# Altium schematic sheet Top Level.SchDoc("Top Level")
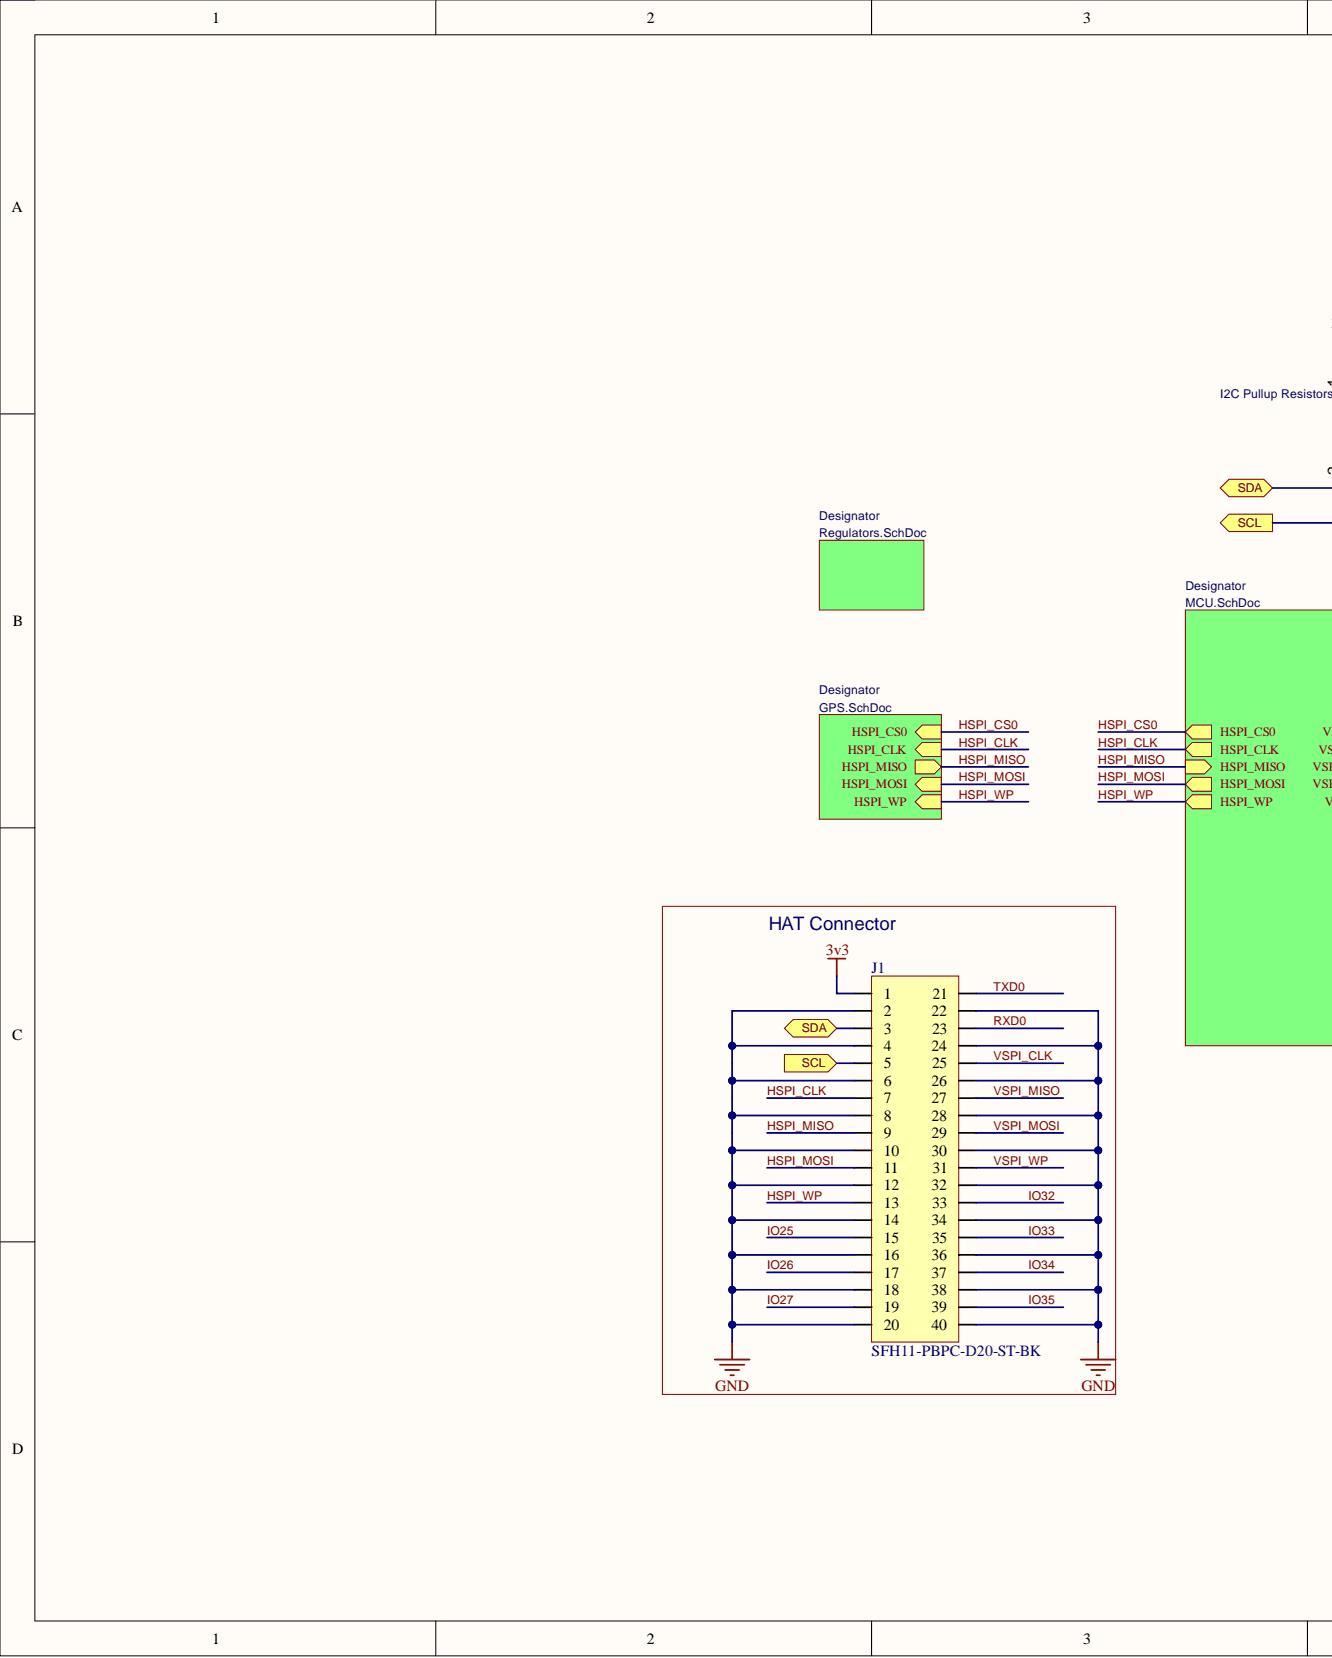
[diagram p0: Top Level.SchDoc, left]
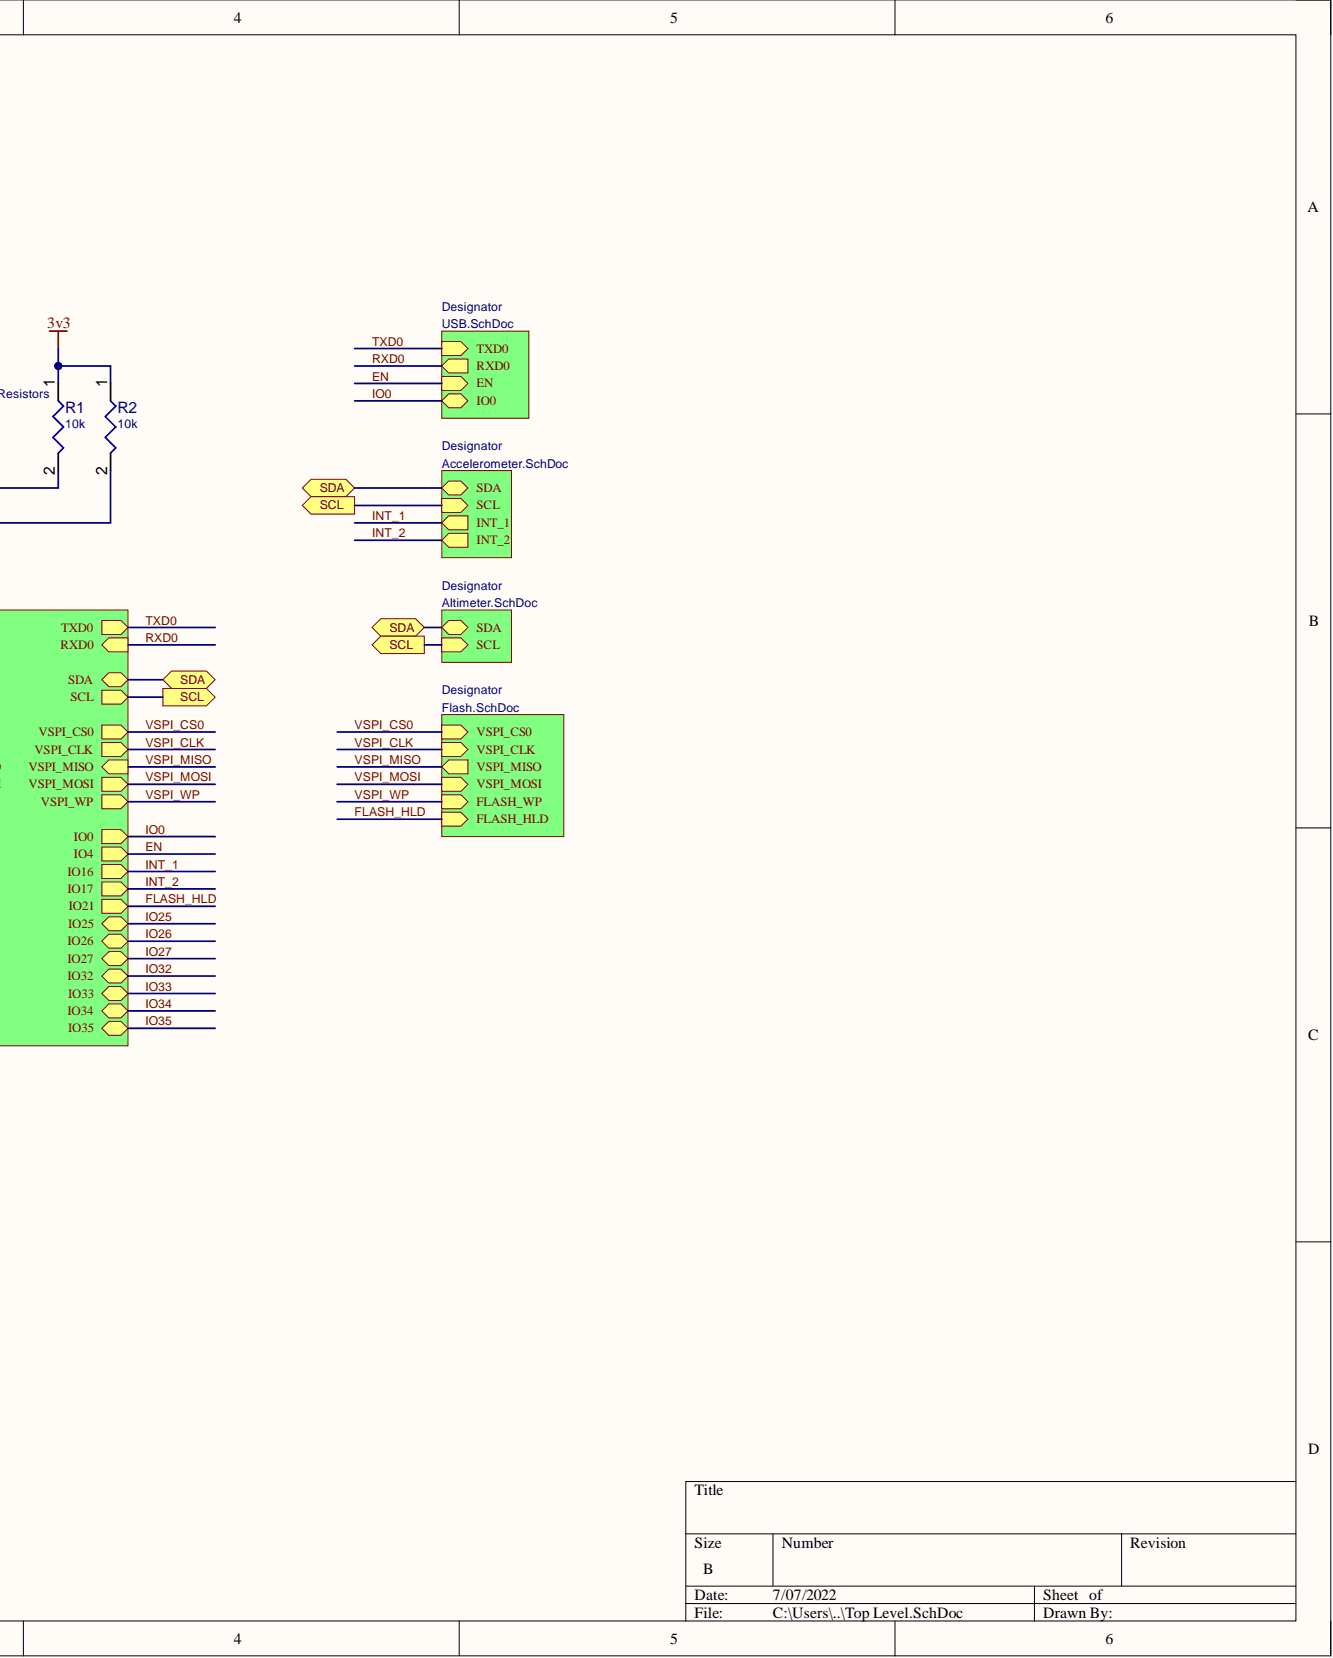
[diagram p1: Top Level.SchDoc, right]

## Parts
J1  SFH11-PBPC-D20-ST-BK  [SULLINS_SFH11-PBPC-D20-ST-BK]
R1  value 10k  [RESC0402(1005)_L]  (hidden comment: Resistor 10K  +/-1 % 0402 63 mW)
R2  value 10k  [RESC0402(1005)_L]  (hidden comment: Resistor 10K  +/-1 % 0402 63 mW)

## Ports
SCL, SDA

## Nets
3v3: J1.1 R1.1 R2.1
GND: J1.2 J1.4 J1.6 J1.8 J1.10 J1.12 J1.14 J1.16 J1.18 J1.20 J1.22 J1.24 J1.26 J1.28 J1.30 J1.32 J1.34 J1.36 J1.38 J1.40
HSPI_CLK: J1.7
HSPI_MISO: J1.9
HSPI_MOSI: J1.11
HSPI_WP: J1.13
IO25: J1.15
IO26: J1.17
IO27: J1.19
IO32: J1.33
IO33: J1.35
IO34: J1.37
IO35: J1.39
RXD0: J1.23
SCL: J1.5 R2.2 ; port SCL
SDA: J1.3 R1.2 ; port SDA
TXD0: J1.21
VSPI_CLK: J1.25
VSPI_MISO: J1.27
VSPI_MOSI: J1.29
VSPI_WP: J1.31
EN:
FLASH_HLD:
HSPI_CS0:
INT_1:
INT_2:
IO0:
VSPI_CS0:

## Library data of the parts
J1 = SFH11-PBPC-D20-ST-BK: 40 Position Header Connector 0.100" (2.54mm) Through Hole Gold; Component Kind: Standard; Library Name: Schlib1.SchLib
R1 R2 = CMP-009-00149-2: Chip Resistor, 10 kOhms, +/-1 %, 63 mW, -55 to 125 degC, 0402; Power: 63mW; Tolerance: 1%; Case/Package: 0402; Component Kind: Standard; Max Operating Temperature: 155°C; Min Operating Temperature: -55°C; Pins: 2; Library Name: RIT Launch Initiative
